# Altium schematic sheet SX1280_e394v02a.SchDoc(SX1280_e394v02a)
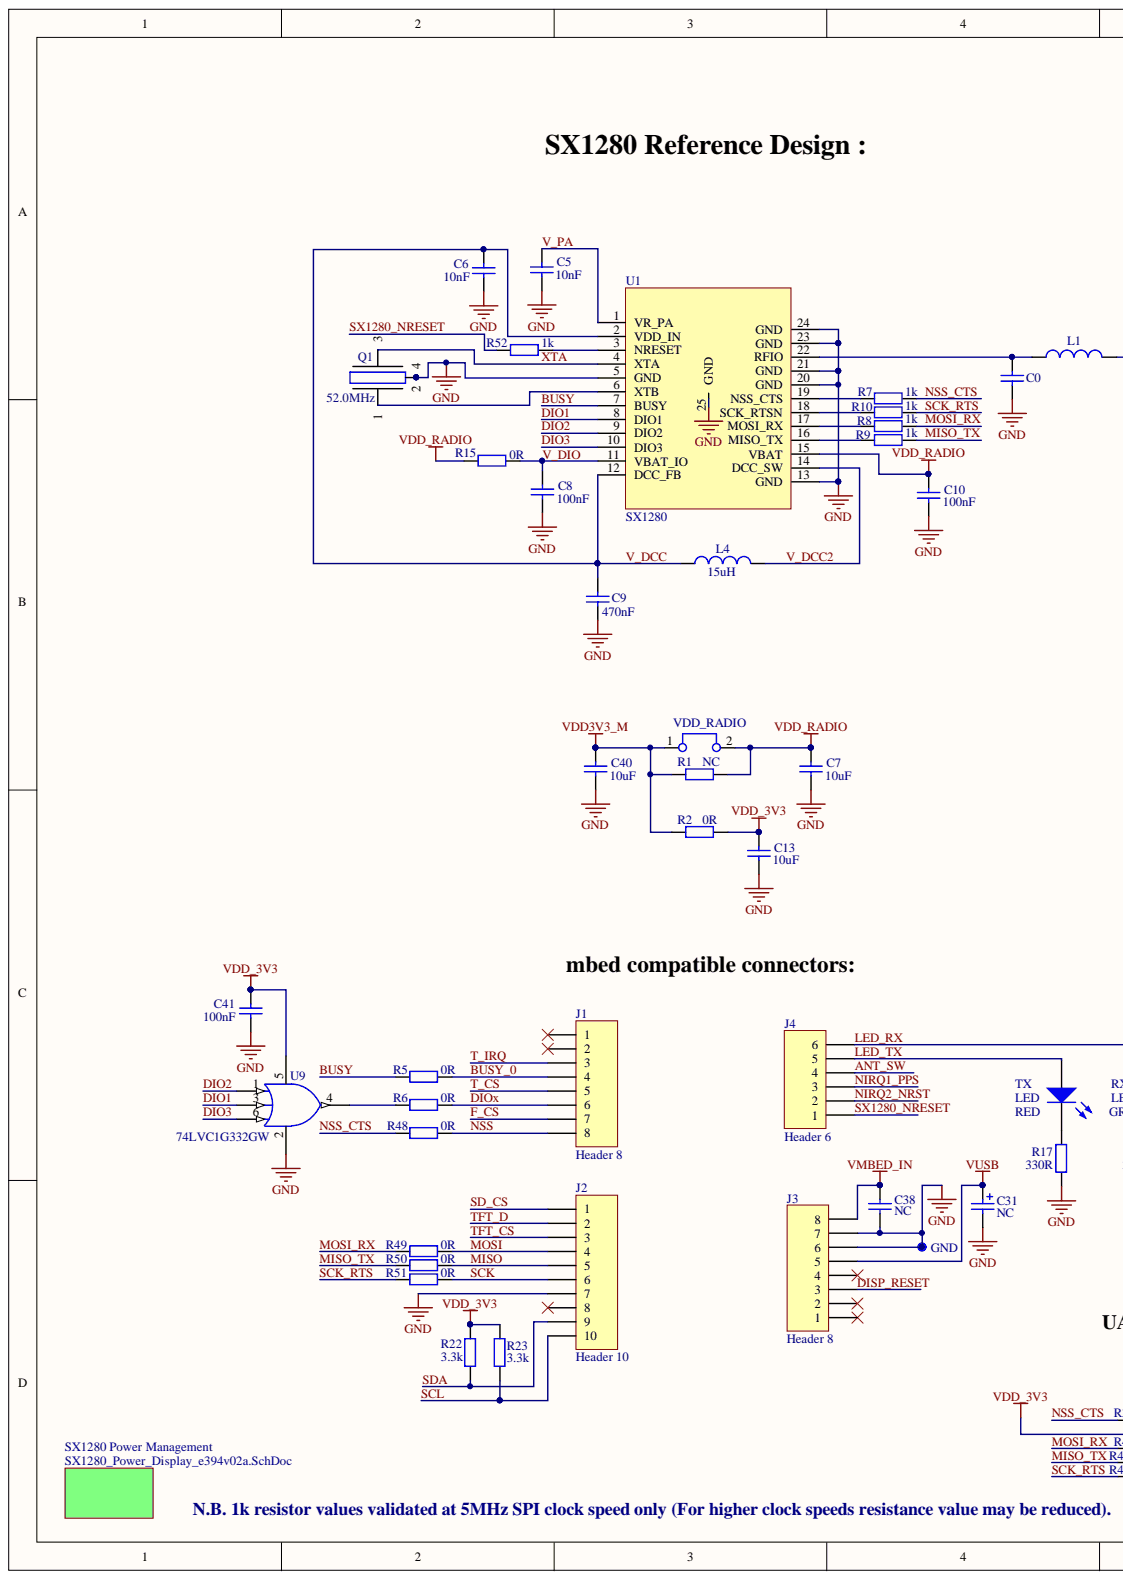
[diagram p0: SX1280_e394v02a.SchDoc, left]
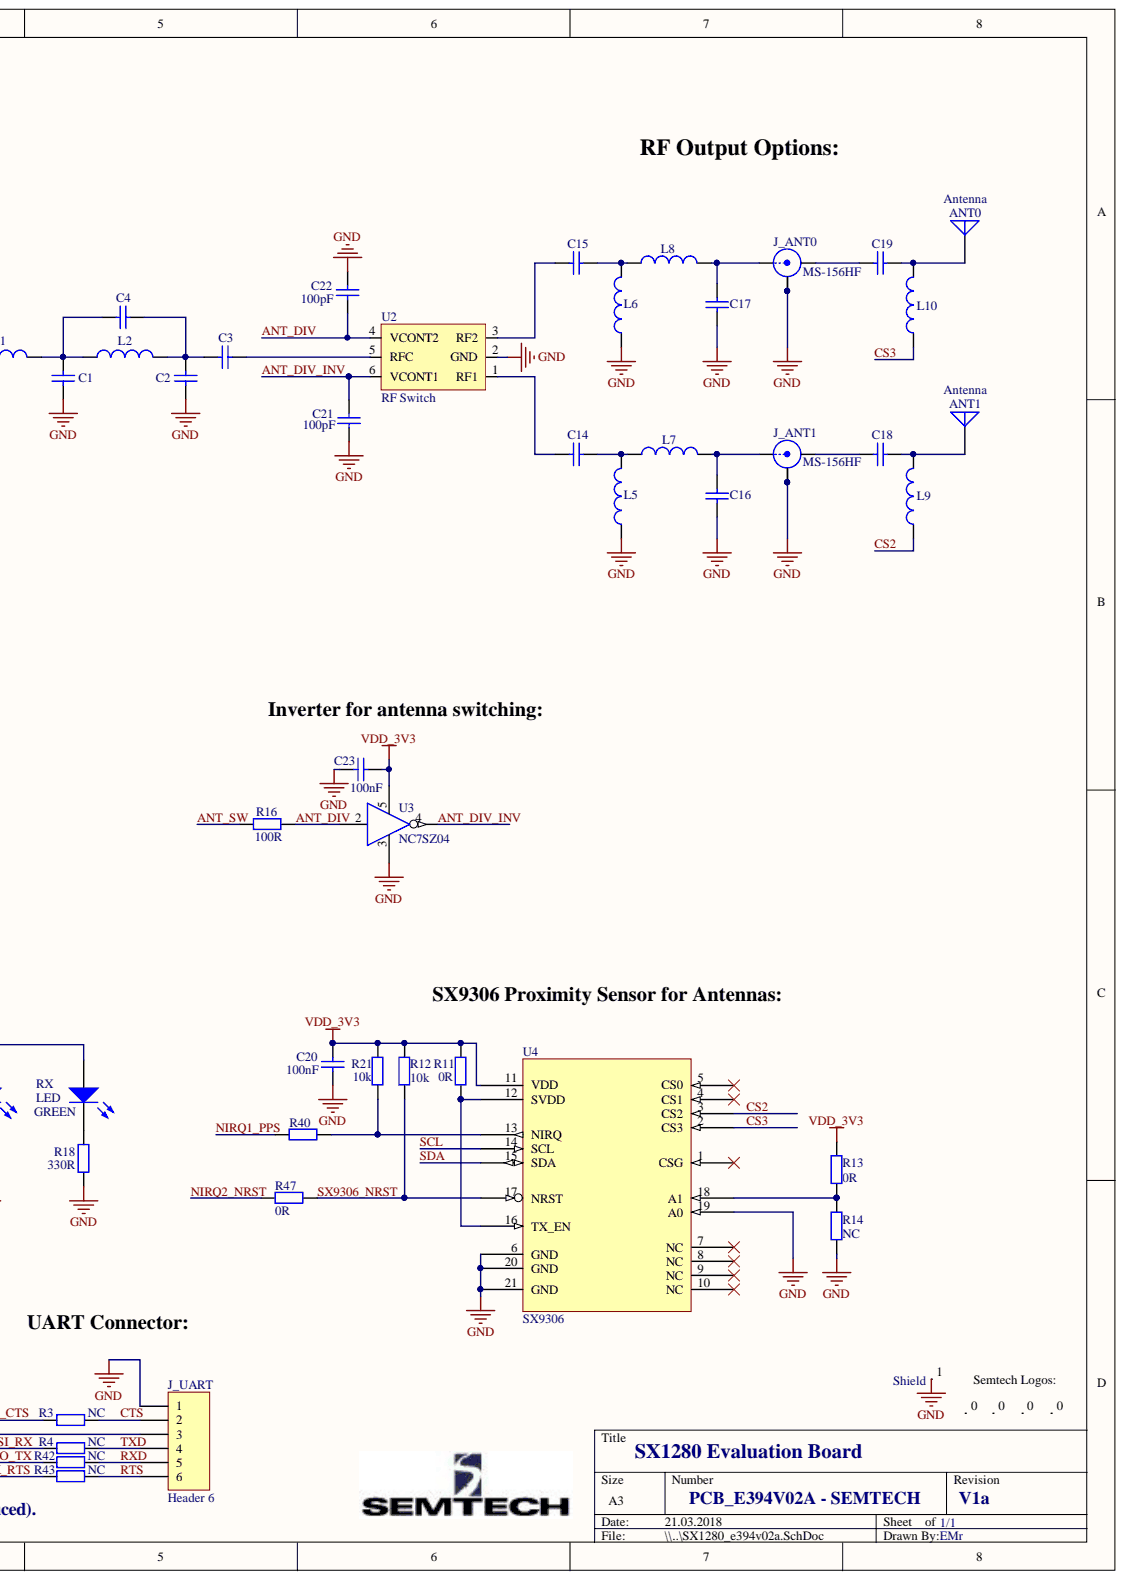
[diagram p1: SX1280_e394v02a.SchDoc, right]

## Parts
ANT0  Antenna  [ANT_2.4GHz_microstrip]
ANT1  Antenna  [ANT_2.4GHz_microstrip]
C0  Cap  [rlc_402_smd]  (value not drawn)
C1  Cap  [rlc_402_smd]  (value not drawn)
C2  Cap  [rlc_402_smd]  (value not drawn)
C3  Cap  [rlc_402_smd]  (value not drawn)
C4  Cap  [rlc_402_smd]  (value not drawn)
C5  value 10nF  [rlc_402_smd]  (hidden comment: Cap)
C6  value 10nF  [rlc_402_smd]  (hidden comment: Cap)
C7  value 10uF  [rlc_805_smd]  (hidden comment: Cap)
C8  value 100nF  [rlc_402_smd]  (hidden comment: Cap)
C9  value 470nF  [rlc_402_smd]  (hidden comment: Cap)
C10  value 100nF  [rlc_402_smd]  (hidden comment: Cap)
C13  value 10uF  [rlc_805_smd]  (hidden comment: Cap)
C14  Cap  [rlc_402_smd]  (value not drawn)
C15  Cap  [rlc_402_smd]  (value not drawn)
C16  Cap  [rlc_402_smd]  (value not drawn)
C17  Cap  [rlc_402_smd]  (value not drawn)
C18  Cap  [rlc_402_smd]  (value not drawn)
C19  Cap  [rlc_402_smd]  (value not drawn)
C20  value 100nF  [rlc_402_smd]  (hidden comment: Cap)
C21  value 100pF  [rlc_402_smd]  (hidden comment: Cap)
C22  value 100pF  [rlc_402_smd]  (hidden comment: Cap)
C23  value 100nF  [rlc_402_smd]  (hidden comment: Cap)
C31  value NC  [CD3216-1206]  (hidden comment: Cap Pol)
C38  value NC  [rlc_805_smd]  (hidden comment: Cap)
C40  value 10uF  [rlc_805_smd]  (hidden comment: Cap)
C41  value 100nF  [rlc_402_smd]  (hidden comment: Cap)
GND  Test Pin  [pin_0.9mm]  (value not drawn)
J1  Header 8  [HDR1X8]
J2  Header 10  [HDR1X10]
J3  Header 8  [HDR1X8]
J4  Header 6  [HDR1X6]
J_ANT0  MS-156HF  [MS-156HF Hirose]
J_ANT1  MS-156HF  [MS-156HF Hirose]
J_UART  Header 6  [HDR1X6]
L1  Inductor  [rlc_402_smd]  (value not drawn)
L2  Inductor  [rlc_402_smd]  (value not drawn)
L4  value 15uH  [rlc_805_smd enlarged]  (hidden comment: Inductor)
L5  Inductor  [rlc_402_smd]  (value not drawn)
L6  Inductor  [rlc_402_smd]  (value not drawn)
L7  Inductor  [rlc_402_smd]  (value not drawn)
L8  Inductor  [rlc_402_smd]  (value not drawn)
L9  Inductor  [rlc_402_smd]  (value not drawn)
L10  Inductor  [rlc_402_smd]  (value not drawn)
Logo_Bot  Logo symbol  [logo_semtech_horiz_size4]  (value not drawn)
Logo_ESD  Logo symbol  [Logo ESD]  (value not drawn)
Logo_Recycling1  Logo symbol  [Logo Recycling1]  (value not drawn)
Logo_Semtech_S  Logo symbol  [logo_semtech_horiz_size3]  (value not drawn)
Q1  value 52.0MHz  [nd2016da]  (hidden comment: Xtal)
R1  value NC  [rlc_603_smd]  (hidden comment: Resistor)
R2  value 0R  [rlc_603_smd]  (hidden comment: Resistor)
R3  value NC  [rlc_402_smd]  (hidden comment: Resistor)
R4  value NC  [rlc_402_smd]  (hidden comment: Resistor)
R5  value 0R  [rlc_402_smd]  (hidden comment: Resistor)
R6  value 0R  [rlc_402_smd]  (hidden comment: Resistor)
R7  value 1k  [rlc_201_smd]  (hidden comment: Resistor)
R8  value 1k  [rlc_201_smd]  (hidden comment: Resistor)
R9  value 1k  [rlc_201_smd]  (hidden comment: Resistor)
R10  value 1k  [rlc_201_smd]  (hidden comment: Resistor)
R11  value 0R  [rlc_402_smd]  (hidden comment: Resistor)
R12  value 10k  [rlc_402_smd]  (hidden comment: Resistor)
R13  value 0R  [rlc_402_smd]  (hidden comment: Resistor)
R14  value NC  [rlc_402_smd]  (hidden comment: Resistor)
R15  value 0R  [rlc_402_smd]  (hidden comment: Resistor)
R16  value 100R  [rlc_402_smd]  (hidden comment: Resistor)
R17  value 330R  [rlc_402_smd]  (hidden comment: Resistor)
R18  value 330R  [rlc_402_smd]  (hidden comment: Resistor)
R21  value 10k  [rlc_402_smd]  (hidden comment: Resistor)
R22  value 3.3k  [rlc_402_smd]  (hidden comment: Resistor)
R23  value 3.3k  [rlc_402_smd]  (hidden comment: Resistor)
R40  Resistor  [rlc_402_smd]  (value not drawn)
R42  value NC  [rlc_402_smd]  (hidden comment: Resistor)
R43  value NC  [rlc_402_smd]  (hidden comment: Resistor)
R47  value 0R  [rlc_402_smd]  (hidden comment: Resistor)
R48  value 0R  [rlc_402_smd]  (hidden comment: Resistor)
R49  value 0R  [rlc_402_smd]  (hidden comment: Resistor)
R50  value 0R  [rlc_402_smd]  (hidden comment: Resistor)
R51  value 0R  [rlc_402_smd]  (hidden comment: Resistor)
R52  value 1k  [rlc_201_smd]  (hidden comment: Resistor)
RX  LED  value GREEN  [LGM676 LED]
Shield  Logo symbol  [BMIS-209-F - Shield]  (value not drawn)
TX  LED  value RED  [LGM676 LED]
U1  SX1280  [vqfn24_4x4mm]
U2  RF Switch  [MiniMold-6]
U3  NC7SZ04  [SC70-5]
U4  SX9306  [vqfn20_3x3mm]
U9  74LVC1G332GW  [SOT363-6]
VDD_RADIO  Jumper  [bridge_254mm]  (value not drawn)

## Nets
GND: C0.2 C1.1 C2.1 C5.1 C6.1 C7.1 C8.1 C9.1 C10.1 C13.1 C16.2 C17.2 C20.2 C21.1 C22.1 C23.1 C31.2 C38.1 C40.1 C41.1 GND.1 J2.7 J3.6 J3.7 J_ANT0.3 J_ANT0.4 J_ANT1.3 J_ANT1.4 J_UART.1 L5.1 L6.1 Q1.2 Q1.4 R14.1 R17.1 R18.1 Shield.1 U1.5(GND) U1.13(GND) U1.20(GND) U1.21(GND) U1.23(GND) U1.24(GND) U1.25 U2.2(GND) U3.3 U4.6(GND) U4.19(A0) U4.20(GND) U4.21(GND) U9.2
VDD3V3_M: C40.2 R1.2 R2.2 VDD_RADIO.1
VDD_3V3: C13.2 C20.1 C23.2 C41.2 J_UART.3 R2.1 R11.1 R12.2 R13.2 R21.2 R22.2 R23.2 U3.5 U4.11(VDD) U9.5
VDD_RADIO: C7.2 C10.2 R1.1 R15.2 U1.15(VBAT) VDD_RADIO.2
VMBED_IN: C38.2 J3.8
VUSB: C31.1 J3.5
ANT_DIV: C22.2 R16.1 U2.4(VCONT2) U3.2
ANT_DIV_INV: C21.2 U2.6(VCONT1) U3.4
ANT_SW: J4.4 R16.2
BUSY: R5.2 U1.7(BUSY)
BUSY_0: J1.4 R5.1
CS2: L9.1 U4.3(CS2)
CS3: L10.1 U4.2(CS3)
CTS: J_UART.2 R3.1
DIO1: U1.8(DIO1) U9.3
DIO2: U1.9(DIO2) U9.1
DIO3: U1.10(DIO3) U9.6
DIOx: J1.6 R6.1
DISP_RESET: J3.3
F_CS: J1.7
LED_RX: J4.6 RX.1
LED_TX: J4.5 TX.1
MISO: J2.5 R50.1
MISO_TX: R9.1 R42.2 R50.2
MOSI: J2.4 R49.1
MOSI_RX: R4.2 R8.1 R49.2
NIRQ1_PPS: J4.3 R40.1
NIRQ2_NRST: J4.2 R47.1
NSS: J1.8 R48.1
NSS_CTS: R3.2 R7.1 R48.2
RTS: J_UART.6 R43.1
RXD: J_UART.5 R42.1
SCK: J2.6 R51.1
SCK_RTS: R10.1 R43.2 R51.2
SCL: J2.10 R23.1 U4.14(SCL)
SD_CS: J2.1
SDA: J2.9 R22.1 U4.15(SDA)
SX1280_NRESET: J4.1 R52.2
SX9306_NRST: R12.1 R47.2 U4.17(NRST)
T_CS: J1.5
T_IRQ: J1.3
TFT_CS: J2.3
TFT_D: J2.2
TXD: J_UART.4 R4.1
V_DCC: C6.2 C9.2 L4.1 U1.2(VDD_IN) U1.12(DCC_FB)
V_DCC2: L4.2 U1.14(DCC_SW)
V_DIO: C8.2 R15.1 U1.11(VBAT_IO)
V_PA: C5.2 U1.1(VR_PA)
XTA: Q1.3 U1.4(XTA)
NetANT0_1: ANT0.1 C19.1 L10.2
NetANT1_1: ANT1.1 C18.1 L9.2
NetC0_1: C0.1 L1.1 U1.22(RFIO)
NetC1_2: C1.2 C4.1 L1.2 L2.1
NetC2_2: C2.2 C3.1 C4.2 L2.2
NetC3_2: C3.2 U2.5(RFC)
NetC14_1: C14.1 L5.2 L7.1
NetC14_2: C14.2 U2.1(RF1)
NetC15_1: C15.1 L6.2 L8.1
NetC15_2: C15.2 U2.3(RF2)
NetC16_1: C16.1 J_ANT1.2 L7.2
NetC17_1: C17.1 J_ANT0.2 L8.2
NetC18_2: C18.2 J_ANT1.1
NetC19_2: C19.2 J_ANT0.1
NetQ1_1: Q1.1 U1.6(XTB)
NetR6_2: R6.2 U9.4
NetR7_2: R7.2 U1.19(NSS_CTS)
NetR8_2: R8.2 U1.17(MOSI_RX)
NetR9_2: R9.2 U1.16(MISO_TX)
NetR10_2: R10.2 U1.18(SCK_RTSN)
NetR11_2: R11.2 U4.12(SVDD) U4.16(TX_EN)
NetR13_1: R13.1 R14.2 U4.18(A1)
NetR17_2: R17.2 TX.2
NetR18_2: R18.2 RX.2
NetR21_1: R21.1 R40.2 U4.13(NIRQ)
NetR52_1: R52.1 U1.3(NRESET)

Not connected: J1 1 2; J2 8; J3 1 2 4; Logo_Bot 0; Logo_ESD 0; Logo_Recycling1 0; Logo_Semtech_S 0; U4 1(CSG) 4(CS1) 5(CS0) 7(NC) 8(NC) 9(NC) 10(NC)

## Library data of the parts
ANT0 ANT1 = Antenna: Generic Antenna; Library Name: SX1280_e394v02a.SchLib; LatestRevisionDate: 21-Feb-2012  -  Semtech; Component Kind: Standard
C0-C4 C14-C19 = Cap: Capacitor SMD; Value: NC; Simulation: CAP; Signal Integrity: Cap Semi; Published: 8-Jun-2000; Library Name: SX1280_e394v02a.SchLib; LatestRevisionNote: Re-released for DXP Platform.; LatestRevisionDate: 05-oct-2007  -  Semtech; Component Kind: Standard; Publisher: Altium Limited
C5-C10 C13 C20-C23 C38 C40 C41 = Cap: Capacitor SMD; Signal Integrity: Cap Semi; Published: 8-Jun-2000; Library Name: SX1280_e394v02a.SchLib; LatestRevisionNote: Re-released for DXP Platform.; LatestRevisionDate: 05-oct-2007  -  Semtech; Component Kind: Standard; Publisher: Altium Limited
  C5-C10 C13 C21-C23 C38 C40 C41: Simulation: CAP
C31 = Cap Pol: Polarized Capacitor (Surface Mount); Simulation: CAP; Signal Integrity: Cap Pol; Published: 8-Jun-2000; Library Name: SX1280_e394v02a.SchLib; LatestRevisionNote: Re-released for DXP Platform.; LatestRevisionDate: 05-oct-2007  -  Semtech; Component Kind: Standard; Publisher: Altium Limited
GND = test_pin: Library Name: SX1280_e394v02a.SchLib; LatestRevisionDate: 05-oct-2007  -  Semtech; Description: Test Pin; Component Kind: Standard
J1 J3 = Header 8: Header, 8-Pin; Signal Integrity: Connector; Library Name: SX1280_e394v02a.SchLib; LatestRevisionNote: Re-released for DXP Platform.; LatestRevisionDate: 17-Jul-2002; Component Kind: Standard; Publisher: Altium Limited
J2 = Header 10: Header, 10-Pin; Signal Integrity: Connector; Library Name: SX1280_e394v02a.SchLib; LatestRevisionNote: Re-released for DXP Platform.; LatestRevisionDate: 17-Jul-2002; Component Kind: Standard; Publisher: Altium Limited
J4 J_UART = Header 6: Header, 6-Pin; Signal Integrity: Connector; Library Name: SX1280_e394v02a.SchLib; LatestRevisionNote: Re-released for DXP Platform.; LatestRevisionDate: 17-Jul-2002; Component Kind: Standard; Publisher: Altium Limited
J_ANT0 J_ANT1 = MS-156HF: MS-156HF Hirose RF Connector; Library Name: SX1280_e394v02a.SchLib; LatestRevisionNote: Re-released for DXP Platform.; LatestRevisionDate: 15-June-2016  -  Semtech; Component Kind: Standard; Publisher: Altium Limited
L1 L2 L5-L10 = Inductor: Inductor SMD; Value: 0R; Code_IEC: 1005; Simulation: INDUCTOR; Signal Integrity: Inductor; Published: 8-Jun-2000; Library Name: SX1280_e394v02a.SchLib; LatestRevisionNote: Re-released for DXP Platform.; LatestRevisionDate: 05-oct-2007  -  Semtech; Component Kind: Standard; Code_JEITA: 0402; Publisher: Altium Limited
L4 = Inductor: Inductor SMD; Code_IEC: 1005; Simulation: INDUCTOR; Signal Integrity: Inductor; Published: 8-Jun-2000; Library Name: SX1280_e394v02a.SchLib; LatestRevisionNote: Re-released for DXP Platform.; LatestRevisionDate: 05-oct-2007  -  Semtech; Component Kind: Standard; Code_JEITA: 0402; Publisher: Altium Limited
Logo_Bot Logo_ESD Logo_Recycling1 Logo_Semtech_S = Logo: Schematic symbol for Logo; Library Name: SX1280_e394v02a.SchLib; Component Kind: Standard
Q1 = Xtal GND: Crystal with GND pins; 4 pins; Library Name: SX1280_e394v02a.SchLib; LatestRevisionDate: 17-04-2014  -  Semtech; Component Kind: Standard
R1-R18 R21-R23 R42 R43 R47-R52 = Resistor: Resistor SMD - IEC symbol version; Library Name: SX1280_e394v02a.SchLib; LatestRevisionDate: 27-Jul-20  -  Semtech; Component Kind: Standard
R40 = Resistor: Resistor SMD - IEC symbol version; Value: NC; Library Name: SX1280_e394v02a.SchLib; LatestRevisionDate: 27-Jul-20  -  Semtech; Component Kind: Standard
Shield = Shield: Shield - EMI Gasket - Standard Surface Mount Shields; Library Name: SX1280_e394v02a.SchLib; Component Kind: Standard
U1 = SX1280: SX1280 - Ultra low power RF transceiver; Library Name: SX1280_e394v02a.SchLib; Component Kind: Standard
U2 = CG2214M6 RF Switch: Library Name: SX1280_e394v02a.SchLib; LatestRevisionDate: 10-Jan-2017 -  Semtech; Description: CG2214M6 RF Switch; Component Kind: Standard
U3 = NC7SZ04: NC7SZ04 - TinyLogic HS Single Inverter - Fairchild Semi; Library Name: SX1280_e394v02a.SchLib; Component Kind: Standard
U4 = SX9306: Ultra Low Power, Smart Proximity Sensor for SAR; Library Name: SX1280_e394v02a.SchLib; Component Kind: Standard
U9 = 74LVC1G332GW: Triple 3-Input OR Gate, 6-Pin; Published: 17-Jul-2002; Library Name: SX1280_e394v02a.SchLib; Component Kind: Standard; Publisher: Altium Limited
VDD_RADIO = Jumper: Jumper Wire; Library Name: SX1280_e394v02a.SchLib; LatestRevisionDate: 05-oct-2007  -  Semtech; Component Kind: Standard
RX TX = LED: Library Name: SX1280_e394v02a.SchLib; LatestRevisionDate: 05-oct-2007  -  Semtech; Description: LED; Component Kind: Standard
